# Altium schematic sheet Input Layer v1.2.SchDoc
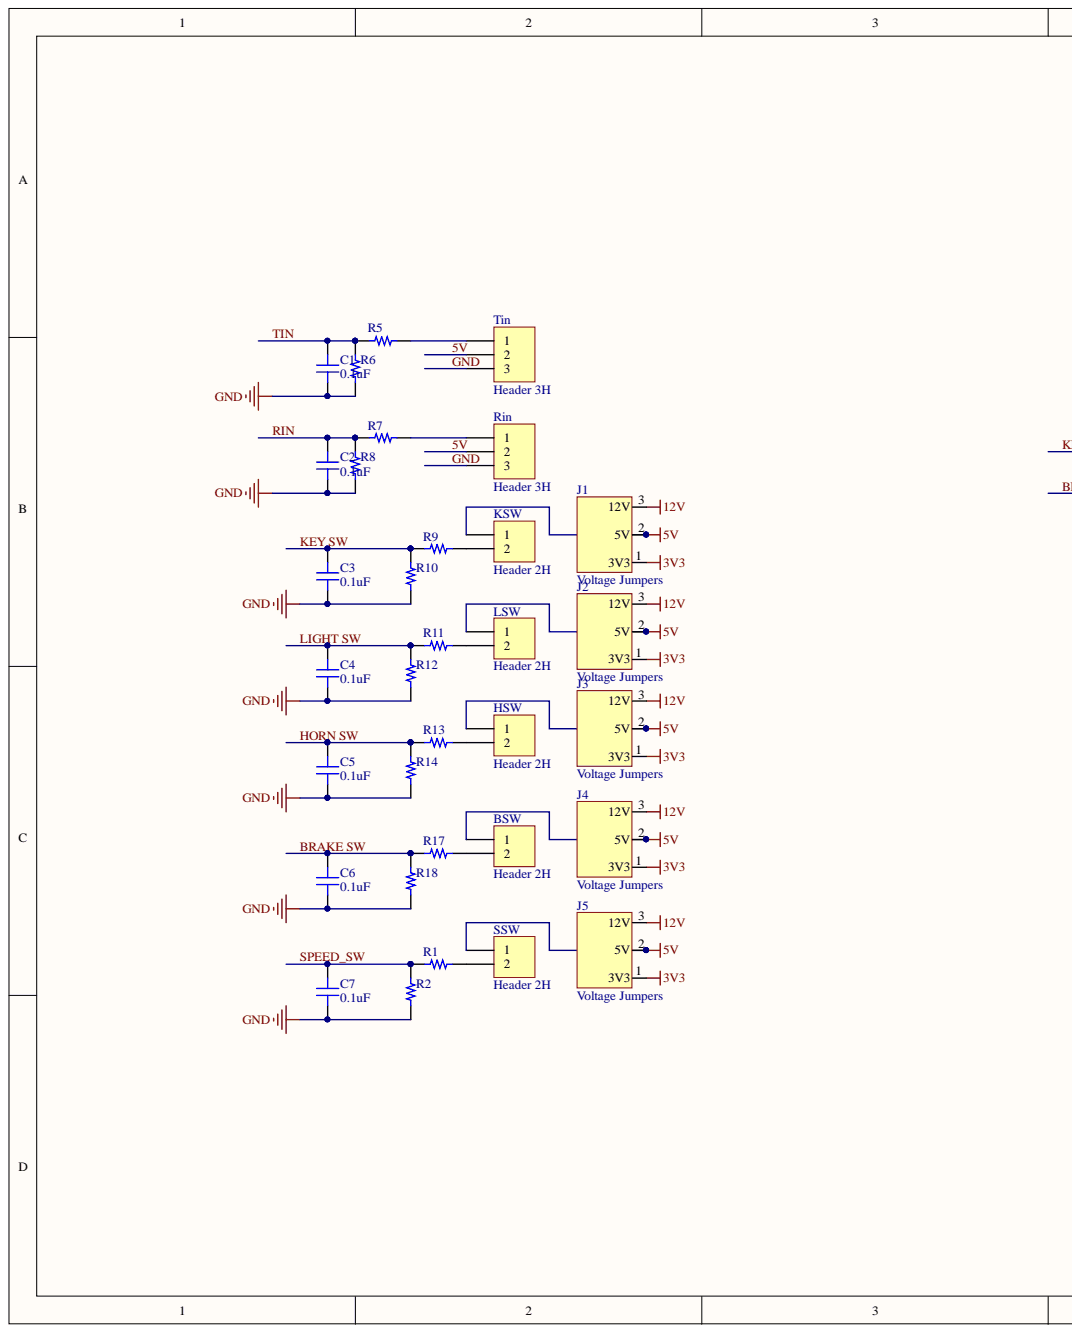
[diagram p0: Input Layer v1.2.SchDoc, left]
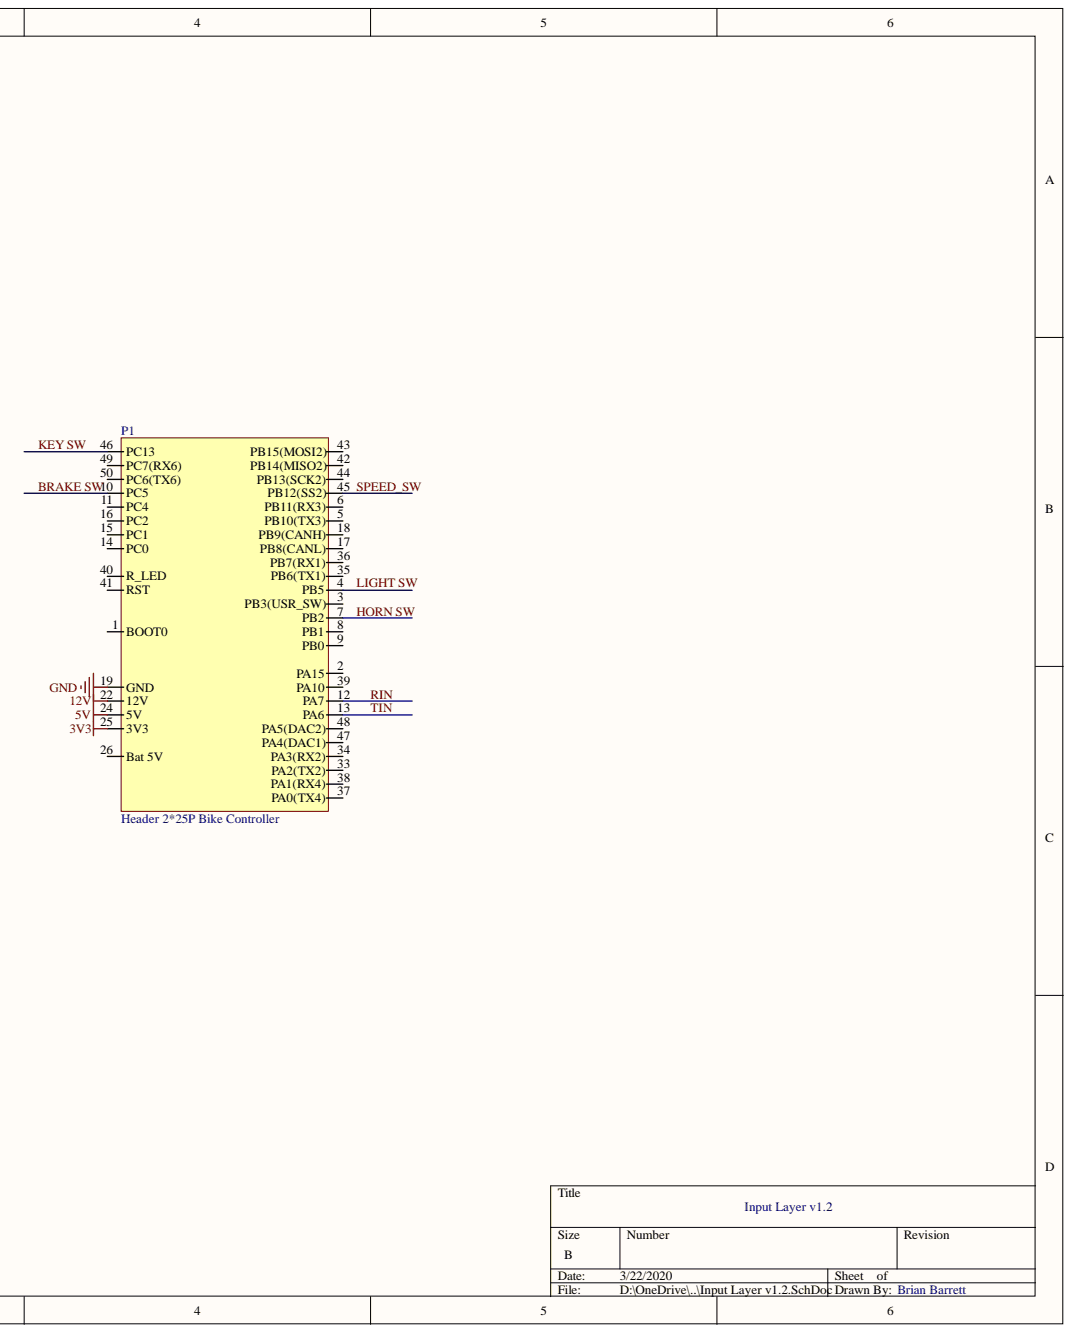
[diagram p1: Input Layer v1.2.SchDoc, right]

## Parts
BSW  Header 2H  [SH 1.0 * 2]
C1  0.1uF  [CAP 0603/1608 RF]
C2  0.1uF  [CAP 0603/1608 RF]
C3  0.1uF  [CAP 0603/1608 RF]
C4  0.1uF  [CAP 0603/1608 RF]
C5  0.1uF  [CAP 0603/1608 RF]
C6  0.1uF  [CAP 0603/1608 RF]
C7  0.1uF  [CAP 0603/1608 RF]
HSW  Header 2H  [SH 1.0 * 2]
J1  Voltage Jumpers  [0603 Voltage Jumper]
J2  Voltage Jumpers  [0603 Voltage Jumper]
J3  Voltage Jumpers  [0603 Voltage Jumper]
J4  Voltage Jumpers  [0603 Voltage Jumper]
J5  Voltage Jumpers  [0603 Voltage Jumper]
KSW  Header 2H  [SH 1.0 * 2]
LSW  Header 2H  [SH 1.0 * 2]
P1  Header 2*25P Bike Controller  [1.0mm 2X25 Header - Dbl Layer]
R1  (no value)  [CAP 0603/1608 RF]
R2  (no value)  [CAP 0603/1608 RF]
R5  (no value)  [CAP 0603/1608 RF]
R6  (no value)  [CAP 0603/1608 RF]
R7  (no value)  [CAP 0603/1608 RF]
R8  (no value)  [CAP 0603/1608 RF]
R9  (no value)  [CAP 0603/1608 RF]
R10  (no value)  [CAP 0603/1608 RF]
R11  (no value)  [CAP 0603/1608 RF]
R12  (no value)  [CAP 0603/1608 RF]
R13  (no value)  [CAP 0603/1608 RF]
R14  (no value)  [CAP 0603/1608 RF]
R17  (no value)  [CAP 0603/1608 RF]
R18  (no value)  [CAP 0603/1608 RF]
Rin  Header 3H  [SH 1.0 * 3]
SSW  Header 2H  [SH 1.0 * 2]
Tin  Header 3H  [SH 1.0 * 3]

## Nets
3V3: J1.1 J2.1 J3.1 J4.1 J5.1 P1.25(3V3)
12V: J1.3 J2.3 J3.3 J4.3 J5.3 P1.22(12V)
5V: BSW.1 HSW.1 J1.2 J2.2 J3.2 J4.2 J5.2 KSW.1 LSW.1 P1.24(5V) Rin.2 SSW.1 Tin.2
BRAKE SW: C6.2 P1.10(PC5) R17.1 R18.2
GND: C1.1 C2.2 C3.1 C4.1 C5.1 C6.1 C7.1 P1.19(GND) R2.1 R6.1 R8.1 R10.1 R12.1 R14.1 R18.1 Rin.3 Tin.3
HORN SW: C5.2 P1.7(PB2) R13.1 R14.2
KEY SW: C3.2 P1.46(PC13) R9.1 R10.2
LIGHT SW: C4.2 P1.4(PB5) R11.1 R12.2
RIN: C2.1 P1.12(PA7) R7.1 R8.2
SPEED_SW: C7.2 P1.45(PB12(SS2)) R1.1 R2.2
TIN: C1.2 P1.13(PA6) R5.1 R6.2
NetBSW_2: BSW.2 R17.2
NetHSW_2: HSW.2 R13.2
NetKSW_2: KSW.2 R9.2
NetLSW_2: LSW.2 R11.2
NetR1_2: R1.2 SSW.2
NetR5_2: R5.2 Tin.1
NetR7_2: R7.2 Rin.1

Not connected: P1 1(BOOT0) 2(PA15) 3(PB3(USR_SW)) 5(PB10(TX3)) 6(PB11(RX3)) 8(PB1) 9(PB0) 11(PC4) 14(PC0) 15(PC1) 16(PC2) 17(PB8(CANL)) 18(PB9(CANH)) 26(Bat_5V) 33(PA2(TX2)) 34(PA3(RX2)) 35(PB6(TX1)) 36(PB7(RX1)) 37(PA0(TX4)) 38(PA1(RX4)) 39(PA10) 40(R_LED) 41(RST) 42(PB14(MISO2)) 43(PB15(MOSI2)) 44(PB13(SCK2)) 47(PA4(DAC1)) 48(PA5(DAC2)) 49(PC7(RX6)) 50(PC6(TX6))

## Library data of the parts
BSW HSW KSW LSW SSW = Header 2H: Header, 2-Pin, Right Angle; Component Kind: Standard; LatestRevisionDate: 17-Jul-2002; LatestRevisionNote: Re-released for DXP Platform.; Library Name: BMI.SchLib; Signal Integrity: Connector; Publisher: Altium Limited
C1-C7 = Capacitor: SMT Capacitor; Component Kind: Standard; Library Name: Passives.SchLib; Value: 0.1uF
P1 = Header 2*25P Bike Controller: Header, 5-Pin, Right Angle; Component Kind: Standard; LatestRevisionDate: 17-Jul-2002; LatestRevisionNote: Re-released for DXP Platform.; Library Name: BMI.SchLib; Publisher: Altium Limited
R1 R2 R5-R14 R17 R18 = Resistor: SMT Resistor; Component Kind: Standard; Library Name: Passives.SchLib
Rin Tin = Header 3H: Header, 3-Pin, Right Angle; Component Kind: Standard; LatestRevisionDate: 17-Jul-2002; LatestRevisionNote: Re-released for DXP Platform.; Library Name: BMI.SchLib; Signal Integrity: Connector; Publisher: Altium Limited
J1-J5 = Voltage Jumpers: Component Kind: Standard; Library Name: BMI.SchLib
